# Altium schematic sheet MainBoard_Power.SchDoc
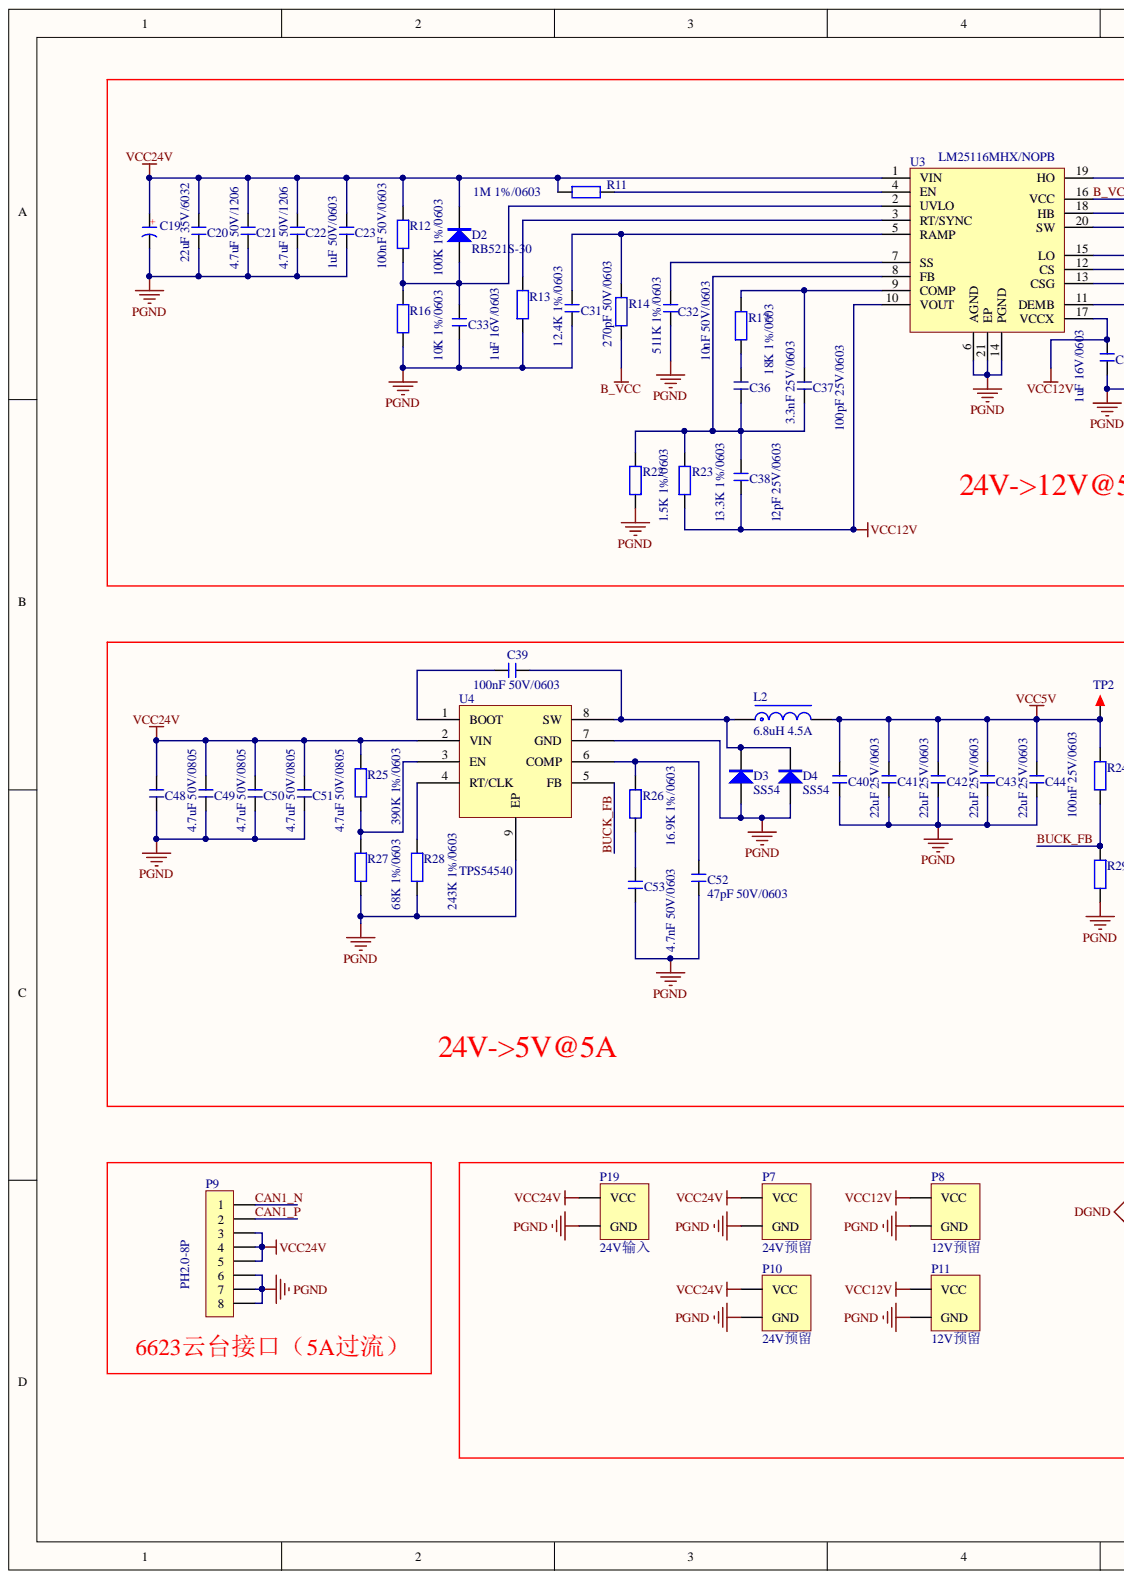
[diagram p0: MainBoard_Power.SchDoc, left]
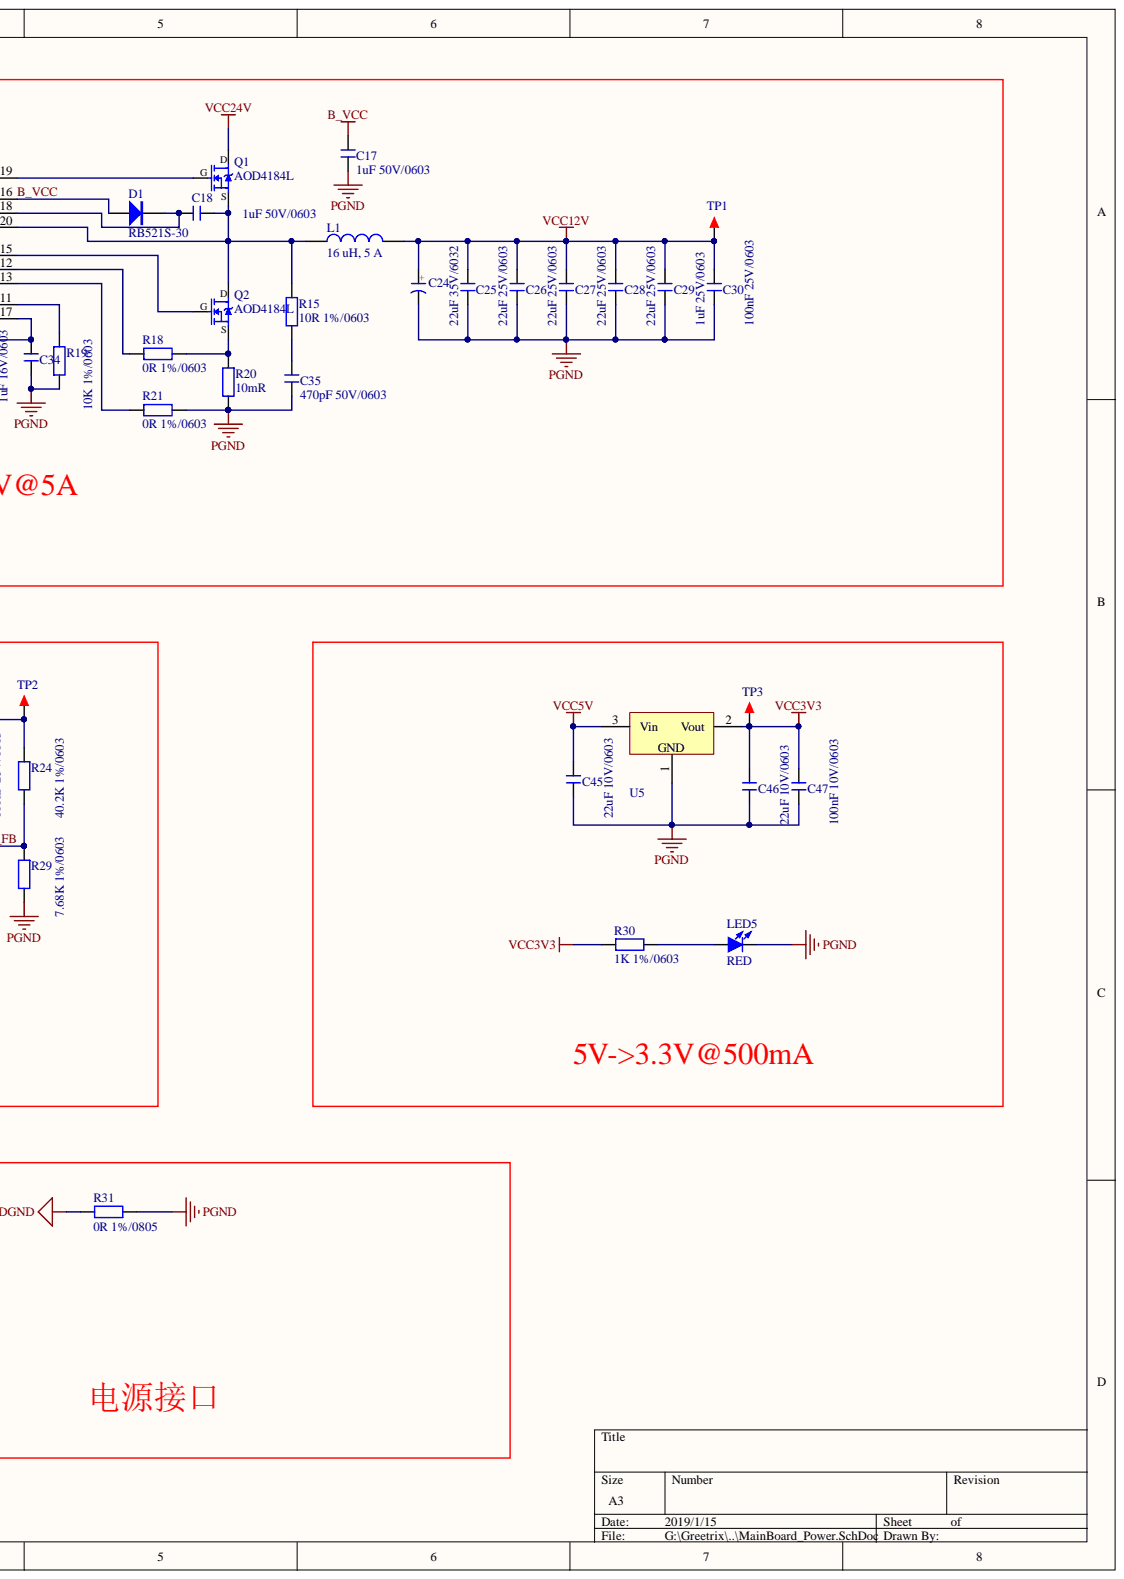
[diagram p1: MainBoard_Power.SchDoc, right]

## Parts
C17  1uF 50V/0603  [C 0603]
C18  1uF 50V/0603  [C 0603]
C19  22uF 35V/6032  [CD C(6032)]
C20  4.7uF 50V/1206  [C 1206]
C21  4.7uF 50V/1206  [C 1206]
C22  1uF 50V/0603  [C 0603]
C23  100nF 50V/0603  [C 0603]
C24  22uF 35V/6032  [CD C(6032)]
C25  22uF 25V/0603  [C 0603]
C26  22uF 25V/0603  [C 0603]
C27  22uF 25V/0603  [C 0603]
C28  22uF 25V/0603  [C 0603]
C29  1uF 25V/0603  [C 0603]
C30  100nF 25V/0603  [C 0603]
C31  270pF 50V/0603  [C 0603]
C32  10nF 50V/0603  [C 0603]
C33  1uF 16V/0603  [C 0603]
C34  1uF 16V/0603  [C 0603]
C35  470pF 50V/0603  [C 0603]
C36  3.3nF 25V/0603  [C 0603]
C37  100pF 25V/0603  [C 0603]
C38  12pF 25V/0603  [C 0603]
C39  100nF 50V/0603  [C 0603]
C40  22uF 25V/0603  [C 0603]
C41  22uF 25V/0603  [C 0603]
C42  22uF 25V/0603  [C 0603]
C43  22uF 25V/0603  [C 0603]
C44  100nF 25V/0603  [C 0603]
C45  22uF 10V/0603  [C 0603]
C46  22uF 10V/0603  [C 0603]
C47  100nF 10V/0603  [C 0603]
C48  4.7uF 50V/0805  [C 0805]
C49  4.7uF 50V/0805  [C 0805]
C50  4.7uF 50V/0805  [C 0805]
C51  4.7uF 50V/0805  [C 0805]
C52  47pF 50V/0603  [C 0603]
C53  4.7nF 50V/0603  [C 0603]
D1  RB521S-30  [SOD-523]
D2  RB521S-30  [SOD-523]
D3  SS54  [SMB]
D4  SS54  [SMB]
L1  16 uH, 5 A  Rated Current: 5 A  [WE-HCI_1050]
L2  6.8uH 4.5A  [L-SMD-PCMC063T]
LED5  RED  [LED 0805]
P7  24V预留  [XT30]
P8  12V预留  [XT30]
P9  PH2.0-8P  [PH2.0-WS-8P]
P10  24V预留  [XT30]
P11  12V预留  [XT30]
P19  24V输入  [XT60]
Q1  AOD4184L  [TO252]
Q2  AOD4184L  [TO252]
R11  1M 1%/0603  [R 0603]
R12  100K 1%/0603  [R 0603]
R13  12.4K 1%/0603  [R 0603]
R14  511K 1%/0603  [R 0603]
R15  10R 1%/0603  [R 0603]
R16  10K 1%/0603  [R 0603]
R17  18K 1%/0603  [R 0603]
R18  0R 1%/0603  [R 0603]
R19  10K 1%/0603  [R 0603]
R20  10mR  [R 0805]
R21  0R 1%/0603  [R 0603]
R22  1.5K 1%/0603  [R 0603]
R23  13.3K 1%/0603  [R 0603]
R24  40.2K 1%/0603  [R 0603]
R25  390K 1%/0603  [R 0603]
R26  16.9K 1%/0603  [R 0603]
R27  68K 1%/0603  [R 0603]
R28  243K 1%/0603  [R 0603]
R29  7.68K 1%/0603  [R 0603]
R30  1K 1%/0603  [R 0603]
R31  0R 1%/0805  [R 0805]
TP1  TEST  [TEST 1.2]  (value not drawn)
TP2  TEST  [TEST 1.2]  (value not drawn)
TP3  TEST  [TEST 1.2]  (value not drawn)
U3  LM25116MHX/NOPB  [TSSOP20_EP]
U4  TPS54540  [SOP8_EP]
U5  AMS1117  [SOT223]  (value not drawn)

## Nets
DGND: R31.1
PGND: C17.1 C19.2 C20.1 C21.1 C22.1 C23.1 C24.2 C25.1 C26.1 C27.1 C28.1 C29.1 C30.1 C31.1 C32.1 C33.1 C34.1 C35.2 C40.1 C41.1 C42.1 C43.1 C44.1 C45.1 C46.1 C47.1 C48.1 C49.1 C50.1 C51.1 C52.2 C53.2 D3.1 D4.1 LED5.2 P7.2 P8.2 P9.6 P9.7 P9.8 P10.2 P11.2 P19.2 R13.2 R16.1 R19.2 R20.1 R21.1 R22.2 R27.1 R28.1 R29.1 R31.2 U3.6(AGND) U3.14(PGND) U3.21(EP) U4.7(GND) U4.9(EP) U5.1
VCC3V3: C46.2 C47.2 R30.2 TP3.1 U5.2
VCC5V: C40.2 C41.2 C42.2 C43.2 C44.2 C45.2 L2.2 R24.2 TP2.1 U5.3
VCC12V: C24.1 C25.2 C26.2 C27.2 C28.2 C29.2 C30.2 C34.2 C38.1 L1.2 P8.1 P11.1 R23.2 TP1.1 U3.10(VOUT) U3.17(VCCX)
VCC24V: C19.1 C20.2 C21.2 C22.2 C23.2 C48.2 C49.2 C50.2 C51.2 D2.2 P7.1 P9.3 P9.4 P9.5 P10.1 P19.1 Q1.2 R11.2 R12.2 R25.2 U3.1(VIN) U4.2(VIN)
B_VCC: C17.2 D1.1 R14.2 U3.16(VCC)
BUCK_FB: R24.1 R29.2 U4.5(FB)
CAN1_N: P9.1
CAN1_P: P9.2
NetC18_1: C18.1 D1.2 U3.18(HB)
NetC18_2: C18.2 L1.1 Q1.3 Q2.2 R15.2 U3.20(SW)
NetC31_2: C31.2 R14.1 U3.5(RAMP)
NetC32_2: C32.2 U3.7(SS)
NetC33_2: C33.2 D2.1 R12.1 R16.2 U3.2(UVLO)
NetC35_1: C35.1 R15.1
NetC36_1: C36.1 C37.1 C38.2 R22.1 R23.1 U3.8(FB)
NetC36_2: C36.2 R17.2
NetC37_2: C37.2 R17.1 U3.9(COMP)
NetC39_1: C39.1 D3.2 D4.2 L2.1 U4.8(SW)
NetC39_2: C39.2 U4.1(BOOT)
NetC52_1: C52.1 R26.2 U4.6(COMP)
NetC53_1: C53.1 R26.1
NetLED5_1: LED5.1 R30.1
NetQ1_1: Q1.1 U3.19(HO)
NetQ2_1: Q2.1 U3.15(LO)
NetQ2_3: Q2.3 R18.1 R20.2
NetR11_1: R11.1 U3.4(EN)
NetR13_1: R13.1 U3.3(RT/SYNC)
NetR18_2: R18.2 U3.12(CS)
NetR19_1: R19.1 U3.11(DEMB)
NetR21_2: R21.2 U3.13(CSG)
NetR25_1: R25.1 R27.2 U4.3(EN)
NetR28_2: R28.2 U4.4(RT/CLK)

## Library data of the parts
C17 C18 C20-C23 C25-C53 = C: 无极性贴片电容; Component Kind: Standard; Library Name: SelfLib_GR.SchLib
C19 C24 = C-CD: 贴片钽电容; Component Kind: Standard; Library Name: SelfLib_GR.SchLib
D1 D2 = RB521S-30: 30V 200mA肖特基二极管 VF=0.5; Component Kind: Standard; Library Name: SelfLib_GR.SchLib
D3 D4 = SS54: 5A 40V 肖特基二极管; Component Kind: Standard; Library Name: SelfLib_GR.SchLib
L1 = 7443251600: SMT Flat Wire High Current Inductor WE-HCI, size 1050, 16 uH, 5 A; DC Resistance max.: 37.95 mOhm; Inductance: 16 uH; Material: Superflux; Rated Inductance: 12.7 uH; Saturation Current: 6.5 A; Self Resonant Frequency: 18 MHz; Component Kind: Standard; ComponentLink1Description: Manufacturer; ComponentLink1URL: http://www.we-online.de; ComponentLink2Description: Datasheet; ComponentLink2URL: https://katalog.we-online.com/pbs/datasheet/7443251600.pdf; Library Name: ALTIUM-WE-HCI-rev18a.IntLib; Mounting Technology: SMT; Operating Temperature: -40 to +150 degC; Packaging: Tape and Reel; Part Number: 7443251600; Published Date: December 2018; Size: 1050; Publisher: Wurth Elektronik
L2 = L-SMD: PCMC063T-6R8M; Component Kind: Standard; Library Name: SelfLib_GR.SchLib
LED5 = LED: 贴片发光二极管; Component Kind: Standard; Library Name: SelfLib_GR.SchLib
P7 P8 P10 P11 = XT30: XT30端子; Component Kind: Standard; Library Name: SelfLib_GR.SchLib
P9 = Header 8P: 8P端子; Component Kind: Standard; Library Name: SelfLib_GR.SchLib
P19 = XT60: XT60端子; Component Kind: Standard; Library Name: SelfLib_GR.SchLib
Q1 Q2 = AOD4184L: N沟道 40V/50A 贴片MOSFET; Component Kind: Standard; Library Name: SelfLib_GR.SchLib
R11-R31 = R: 贴片电阻; Component Kind: Standard; Library Name: SelfLib_GR.SchLib
TP1-TP3 = TEST: 测试点; Component Kind: Standard; Library Name: SelfLib_GR.SchLib
U3 = LM25116MHX/NOPB: Wide Range Synchronous Buck Controller; Component Kind: Standard; Library Name: SelfLib_GR.SchLib
U4 = TPS54540: 4.5-42V 5A BUCK电源芯片; Component Kind: Standard; Library Name: SelfLib_GR.SchLib
U5 = AMS1117: 三端稳压5V->3.3V; Component Kind: Standard; Library Name: SelfLib_GR.SchLib
